# Altium schematic sheet Unused_Pins.SchDoc
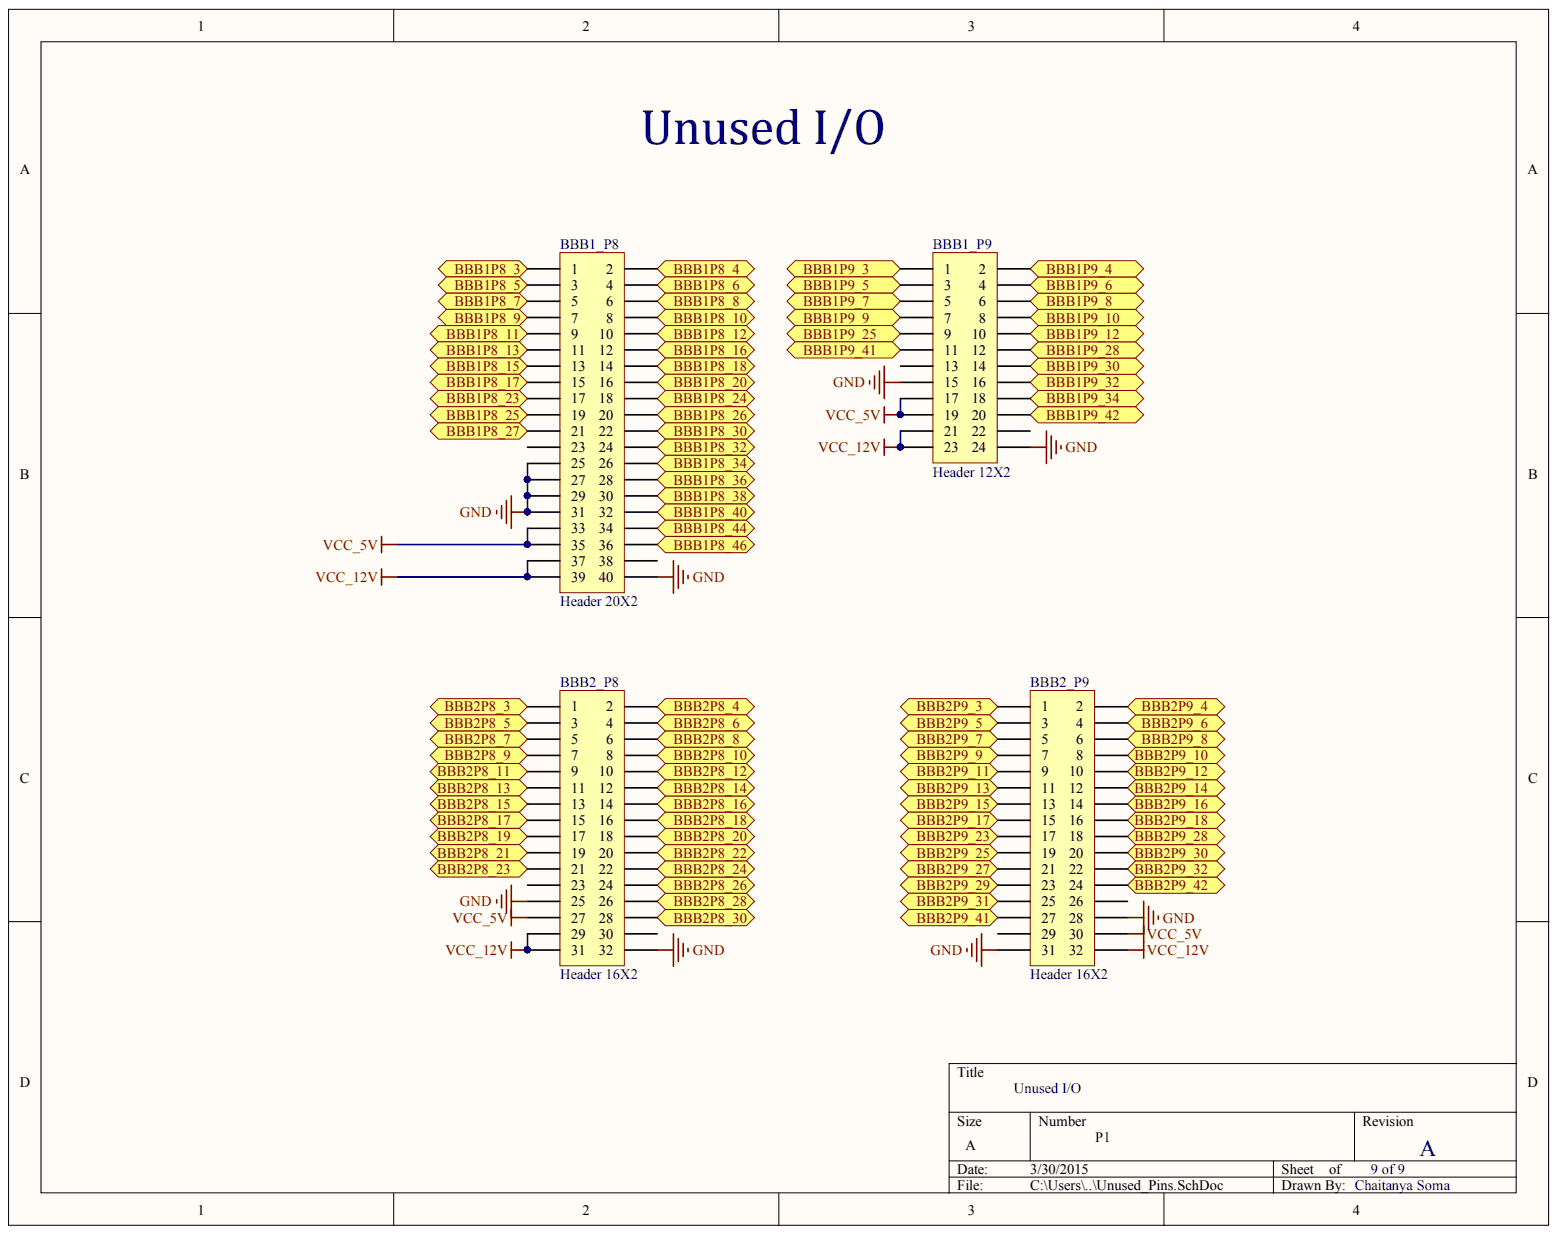
[diagram p0: Unused_Pins.SchDoc]

## Parts
BBB1_P8  Header 20X2  [HDR2X20]
BBB1_P9  Header 12X2  [HDR2X12]
BBB2_P8  Header 16X2  [HDR2X16]
BBB2_P9  Header 16X2  [HDR2X16]

## Ports
BBB1P8_3, BBB1P8_4, BBB1P8_5, BBB1P8_6, BBB1P8_7, BBB1P8_8, BBB1P8_9, BBB1P8_10, BBB1P8_11, BBB1P8_12, BBB1P8_13, BBB1P8_15, BBB1P8_16, BBB1P8_17, BBB1P8_18, BBB1P8_20, BBB1P8_23, BBB1P8_24, BBB1P8_25, BBB1P8_26, BBB1P8_27, BBB1P8_30, BBB1P8_32, BBB1P8_34, BBB1P8_36, BBB1P8_38, BBB1P8_40, BBB1P8_44, BBB1P8_46, BBB1P9_3, BBB1P9_4, BBB1P9_5, BBB1P9_6, BBB1P9_7, BBB1P9_8, BBB1P9_9, BBB1P9_10, BBB1P9_12, BBB1P9_25, BBB1P9_28, BBB1P9_30, BBB1P9_32, BBB1P9_34, BBB1P9_41, BBB1P9_42, BBB2P8_3, BBB2P8_4, BBB2P8_5, BBB2P8_6, BBB2P8_7, BBB2P8_8, BBB2P8_9, BBB2P8_10, BBB2P8_11, BBB2P8_12, BBB2P8_13, BBB2P8_14, BBB2P8_15, BBB2P8_16, BBB2P8_17, BBB2P8_18, BBB2P8_19, BBB2P8_20, BBB2P8_21, BBB2P8_22, BBB2P8_23, BBB2P8_24, BBB2P8_26, BBB2P8_28, BBB2P8_30, BBB2P9_3, BBB2P9_4, BBB2P9_5, BBB2P9_6, BBB2P9_7, BBB2P9_8, BBB2P9_9, BBB2P9_10, BBB2P9_11, BBB2P9_12, BBB2P9_13, BBB2P9_14, BBB2P9_15, BBB2P9_16, BBB2P9_17, BBB2P9_18, BBB2P9_23, BBB2P9_25, BBB2P9_27, BBB2P9_28, BBB2P9_29, BBB2P9_30, BBB2P9_31, BBB2P9_32, BBB2P9_41, BBB2P9_42

## Nets
GND: BBB1_P8.25 BBB1_P8.27 BBB1_P8.29 BBB1_P8.31 BBB1_P8.40 BBB1_P9.15 BBB1_P9.24 BBB2_P8.25 BBB2_P8.32 BBB2_P9.28 BBB2_P9.31
VCC_5V: BBB1_P8.33 BBB1_P8.35 BBB1_P9.17 BBB1_P9.19 BBB2_P8.27 BBB2_P9.30
VCC_12V: BBB1_P8.37 BBB1_P8.39 BBB1_P9.21 BBB1_P9.23 BBB2_P8.29 BBB2_P8.31 BBB2_P9.32
BBB1P8_3: BBB1_P8.1 ; port BBB1P8_3
BBB1P8_4: BBB1_P8.2 ; port BBB1P8_4
BBB1P8_5: BBB1_P8.3 ; port BBB1P8_5
BBB1P8_6: BBB1_P8.4 ; port BBB1P8_6
BBB1P8_7: BBB1_P8.5 ; port BBB1P8_7
BBB1P8_8: BBB1_P8.6 ; port BBB1P8_8
BBB1P8_9: BBB1_P8.7 ; port BBB1P8_9
BBB1P8_10: BBB1_P8.8 ; port BBB1P8_10
BBB1P8_11: BBB1_P8.9 ; port BBB1P8_11
BBB1P8_12: BBB1_P8.10 ; port BBB1P8_12
BBB1P8_13: BBB1_P8.11 ; port BBB1P8_13
BBB1P8_15: BBB1_P8.13 ; port BBB1P8_15
BBB1P8_16: BBB1_P8.12 ; port BBB1P8_16
BBB1P8_17: BBB1_P8.15 ; port BBB1P8_17
BBB1P8_18: BBB1_P8.14 ; port BBB1P8_18
BBB1P8_20: BBB1_P8.16 ; port BBB1P8_20
BBB1P8_23: BBB1_P8.17 ; port BBB1P8_23
BBB1P8_24: BBB1_P8.18 ; port BBB1P8_24
BBB1P8_25: BBB1_P8.19 ; port BBB1P8_25
BBB1P8_26: BBB1_P8.20 ; port BBB1P8_26
BBB1P8_27: BBB1_P8.21 ; port BBB1P8_27
BBB1P8_30: BBB1_P8.22 ; port BBB1P8_30
BBB1P8_32: BBB1_P8.24 ; port BBB1P8_32
BBB1P8_34: BBB1_P8.26 ; port BBB1P8_34
BBB1P8_36: BBB1_P8.28 ; port BBB1P8_36
BBB1P8_38: BBB1_P8.30 ; port BBB1P8_38
BBB1P8_40: BBB1_P8.32 ; port BBB1P8_40
BBB1P8_44: BBB1_P8.34 ; port BBB1P8_44
BBB1P8_46: BBB1_P8.36 ; port BBB1P8_46
BBB1P9_3: BBB1_P9.1 ; port BBB1P9_3
BBB1P9_4: BBB1_P9.2 ; port BBB1P9_4
BBB1P9_5: BBB1_P9.3 ; port BBB1P9_5
BBB1P9_6: BBB1_P9.4 ; port BBB1P9_6
BBB1P9_7: BBB1_P9.5 ; port BBB1P9_7
BBB1P9_8: BBB1_P9.6 ; port BBB1P9_8
BBB1P9_9: BBB1_P9.7 ; port BBB1P9_9
BBB1P9_10: BBB1_P9.8 ; port BBB1P9_10
BBB1P9_12: BBB1_P9.10 ; port BBB1P9_12
BBB1P9_25: BBB1_P9.9 ; port BBB1P9_25
BBB1P9_28: BBB1_P9.12 ; port BBB1P9_28
BBB1P9_30: BBB1_P9.14 ; port BBB1P9_30
BBB1P9_32: BBB1_P9.16 ; port BBB1P9_32
BBB1P9_34: BBB1_P9.18 ; port BBB1P9_34
BBB1P9_41: BBB1_P9.11 ; port BBB1P9_41
BBB1P9_42: BBB1_P9.20 ; port BBB1P9_42
BBB2P8_3: BBB2_P8.1 ; port BBB2P8_3
BBB2P8_4: BBB2_P8.2 ; port BBB2P8_4
BBB2P8_5: BBB2_P8.3 ; port BBB2P8_5
BBB2P8_6: BBB2_P8.4 ; port BBB2P8_6
BBB2P8_7: BBB2_P8.5 ; port BBB2P8_7
BBB2P8_8: BBB2_P8.6 ; port BBB2P8_8
BBB2P8_9: BBB2_P8.7 ; port BBB2P8_9
BBB2P8_10: BBB2_P8.8 ; port BBB2P8_10
BBB2P8_11: BBB2_P8.9 ; port BBB2P8_11
BBB2P8_12: BBB2_P8.10 ; port BBB2P8_12
BBB2P8_13: BBB2_P8.11 ; port BBB2P8_13
BBB2P8_14: BBB2_P8.12 ; port BBB2P8_14
BBB2P8_15: BBB2_P8.13 ; port BBB2P8_15
BBB2P8_16: BBB2_P8.14 ; port BBB2P8_16
BBB2P8_17: BBB2_P8.15 ; port BBB2P8_17
BBB2P8_18: BBB2_P8.16 ; port BBB2P8_18
BBB2P8_19: BBB2_P8.17 ; port BBB2P8_19
BBB2P8_20: BBB2_P8.18 ; port BBB2P8_20
BBB2P8_21: BBB2_P8.19 ; port BBB2P8_21
BBB2P8_22: BBB2_P8.20 ; port BBB2P8_22
BBB2P8_23: BBB2_P8.21 ; port BBB2P8_23
BBB2P8_24: BBB2_P8.22 ; port BBB2P8_24
BBB2P8_26: BBB2_P8.24 ; port BBB2P8_26
BBB2P8_28: BBB2_P8.26 ; port BBB2P8_28
BBB2P8_30: BBB2_P8.28 ; port BBB2P8_30
BBB2P9_3: BBB2_P9.1 ; port BBB2P9_3
BBB2P9_4: BBB2_P9.2 ; port BBB2P9_4
BBB2P9_5: BBB2_P9.3 ; port BBB2P9_5
BBB2P9_6: BBB2_P9.4 ; port BBB2P9_6
BBB2P9_7: BBB2_P9.5 ; port BBB2P9_7
BBB2P9_8: BBB2_P9.6 ; port BBB2P9_8
BBB2P9_9: BBB2_P9.7 ; port BBB2P9_9
BBB2P9_10: BBB2_P9.8 ; port BBB2P9_10
BBB2P9_11: BBB2_P9.9 ; port BBB2P9_11
BBB2P9_12: BBB2_P9.10 ; port BBB2P9_12
BBB2P9_13: BBB2_P9.11 ; port BBB2P9_13
BBB2P9_14: BBB2_P9.12 ; port BBB2P9_14
BBB2P9_15: BBB2_P9.13 ; port BBB2P9_15
BBB2P9_16: BBB2_P9.14 ; port BBB2P9_16
BBB2P9_17: BBB2_P9.15 ; port BBB2P9_17
BBB2P9_18: BBB2_P9.16 ; port BBB2P9_18
BBB2P9_23: BBB2_P9.17 ; port BBB2P9_23
BBB2P9_25: BBB2_P9.19 ; port BBB2P9_25
BBB2P9_27: BBB2_P9.21 ; port BBB2P9_27
BBB2P9_28: BBB2_P9.18 ; port BBB2P9_28
BBB2P9_29: BBB2_P9.23 ; port BBB2P9_29
BBB2P9_30: BBB2_P9.20 ; port BBB2P9_30
BBB2P9_31: BBB2_P9.25 ; port BBB2P9_31
BBB2P9_32: BBB2_P9.22 ; port BBB2P9_32
BBB2P9_41: BBB2_P9.27 ; port BBB2P9_41
BBB2P9_42: BBB2_P9.24 ; port BBB2P9_42

Not connected: BBB1_P8 23 38; BBB1_P9 13 22; BBB2_P8 23 30; BBB2_P9 26 29

## Library data of the parts
BBB1_P8 = Header 20X2: Header, 20-Pin, Dual row; Component Cost: 2.84000; Component Link Descriptions: CONN HEADER FMAL 40PS.1" DL GOLD; Component Kind: Standard; Component Link URL: http://www.digikey.com/product-detail/en/PPPC202LFBN-RC/S7123-ND/810259; Digikey PN: S7123-ND; LatestRevisionDate: 17-Jul-2002; LatestRevisionNote: Re-released for DXP Platform.; Library Name: Miscellaneous Connectors.IntLib; Signal Integrity: Connector; Publisher: Altium Limited
BBB1_P9 = Header 12X2: Header, 12-Pin, Dual row; Component Cost: 1.81000; Component Link Descriptions: CONN HEADER FMAL 24PS.1" DL GOLD; Component Kind: Standard; Component Link URL: http://www.digikey.com/product-detail/en/PPPC122LFBN-RC/S7115-ND/810251; Digikey PN: S7115-ND; LatestRevisionDate: 17-Jul-2002; LatestRevisionNote: Re-released for DXP Platform.; Library Name: Miscellaneous Connectors.IntLib; Signal Integrity: Connector; Publisher: Altium Limited
BBB2_P8 BBB2_P9 = Header 16X2: Header, 16-Pin, Dual row; Component Cost: 2.27000; Component Link Descriptions: CONN HEADER FMAL 32PS.1" DL GOLD; Component Kind: Standard; Component Link URL: http://www.digikey.com/product-detail/en/PPPC162LFBN-RC/S7119-ND/810255; Digikey PN: S7119-ND; LatestRevisionDate: 17-Jul-2002; LatestRevisionNote: Re-released for DXP Platform.; Library Name: Miscellaneous Connectors.IntLib; Signal Integrity: Connector; Publisher: Altium Limited
